# Altium schematic sheet Э3("All Documents",Physical)
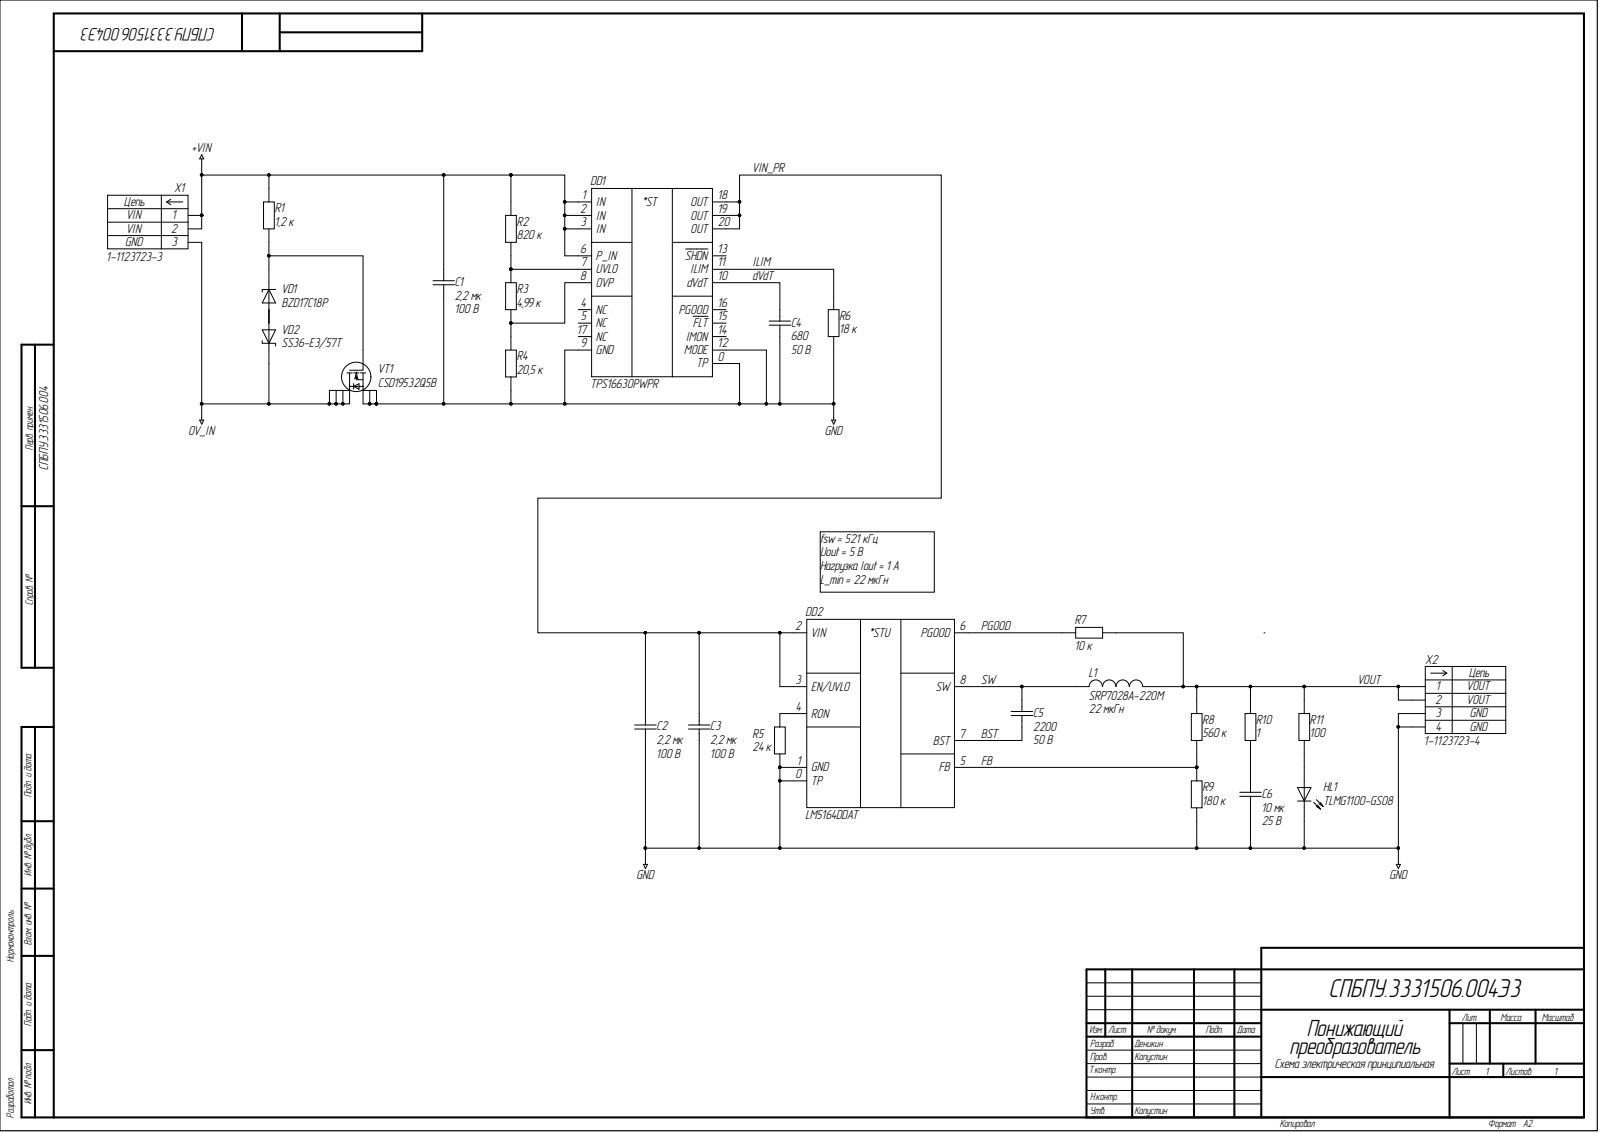
[diagram p0: Э3("All Documents",Physical)]

## Parts
C1  2,2 мк  Номинальное напряжение: 100 В  [C1206]
C2  2,2 мк  [C1206]
C3  2,2 мк  Номинальное напряжение: 100 В  [C1206]
C4  680  [C0603]
C5  2200  Номинальное напряжение: 50 В  [C0603]
C6  10 мк  Номинальное напряжение: 25 В  [C1206]
DD1  TPS16630PWPR  [HTSSOP-20]
DD2  LM5164DDAT  [HSOIC-8-P127-490X390X170-L84X41-T310X240]
HL1  TLMG1100-GS08  [HL0603]
L1  SRP7028A-220M  Номинал: 22 мкГн  [SRP-2-670X660X280]
R1  1,2 к  [R0603]
R2  820 к  [R0603]
R3  4,99 к  [R0603]
R4  20,5 к  [R0603]
R5  24 к  [R0603]
R6  18 к  [R0603]
R7  10 к  [R0603]
R8  560 к  [R0603]
R9  180 к  [R0603]
R10  1  [R0603]
R11  100  [R0603]
VD1  BZD17C18P  [DO-219AB]
VD2  SS36-E3/57T  [DO-214AB]
VT1  CSD19532Q5B  [SON-8]
X1  1-1123723-3  Contact_3: GND  Contact_2: VIN  [1-1123723-3]
X2  1-1123723-4  Contact_4: GND  Contact_2: VOUT  [1-1123723-4]

## Nets
0V_IN: VD2.1 VT1.D X1.3
+VIN: C1.2 DD1.1(IN) DD1.2(IN) DD1.3(IN) DD1.6(P_IN) R1.1 R2.1 X1.1 X1.2
GND: C1.1 C2.1 C3.1 C4.1 C6.1 DD1.0(TP) DD1.9(GND) DD1.12(MODE) DD2.0(TP) DD2.1(GND) HL1.1 R4.1 R5.2 R6.2 R9.2 VT1.S X2.3 X2.4
BST: C5.1 DD2.7(BST)
dVdT: C4.2 DD1.10(dVdT)
FB: DD2.5(FB) R8.2 R9.1
ILIM: DD1.11(ILIM) R6.1
PGOOD: DD2.6(PGOOD) R7.2
SW: C5.2 DD2.8(SW) L1.1
VIN_PR: C2.2 C3.2 DD1.18(OUT) DD1.19(OUT) DD1.20(OUT) DD2.2(VIN) DD2.3(EN/UVLO)
VOUT: L1.2 R7.1 R8.1 R10.1 R11.1 X2.1 X2.2
NetC6_2: C6.2 R10.2
NetDD1_4: DD1.4(NC)
NetDD1_5: DD1.5(NC)
NetDD1_7: DD1.7(UVLO) R2.2 R3.1
NetDD1_8: DD1.8(OVP) R3.2 R4.2
NetDD1_13: DD1.13(SHDN)
NetDD1_14: DD1.14(IMON)
NetDD1_15: DD1.15(FLT)
NetDD1_16: DD1.16(PGOOD)
NetDD1_17: DD1.17(NC)
NetDD2_4: DD2.4(RON) R5.1
NetHL1_2: HL1.2 R11.2
NetR1_2: R1.2 VD1.1 VT1.G
NetVD1_2: VD1.2 VD2.2
N00022:

## Library data of the parts
C1 = c0077: =Описание; Фамилия: Ларионов; Температурный коэффициент емкости: X7R; Рабочие температуры: от –55 °С до +125 °С; Производитель: YAGEO Corp.; Отклонение: ±10 %; Описание: Конденсатор чип 1206 2,2 мкФ 100 В ±10 %; Наименование: CC1206KKX7R0BB225; Корпус: 1206; Database Table Name: Конденсаторы$; Номинал: 2,2 мк; Напряжение: 100 В; ID: c0077; HelpURL: https://www.yageo.com/upload/media/product/productsearch/datasheet/mlcc/UPY-NP0X7R_MV_100-to-630V_22.pdf; Description: Конденсатор чип 1206 2,2 мкФ 100 В ±10 %; Component Kind: Standard; Library Name: Database_Students.DbLib
C2 = c0076: =Описание; Фамилия: Ларионов; Температурный коэффициент емкости: X7R; Рабочие температуры: от –55 °С до +125 °С; Производитель: YAGEO Corp.; Отклонение: ±10 %; Описание: Конденсатор чип 1206 2,2 мкФ 100 В ±10 %; Номинальное напряжение: 100 В; Наименование: CC1206KKX7R0BB225; Корпус: 1206; Database Table Name: Конденсаторы$; Номинал: 2,2 мк; Напряжение: 100 В; ID: c0076; HelpURL: https://www.yageo.com/upload/media/product/productsearch/datasheet/mlcc/UPY-NP0X7R_MV_100-to-630V_22.pdf; Description: Конденсатор чип 1206 2,2 мкФ 100 В ±10 %; Component Kind: Standard; Library Name: Database_Students.DbLib
C3 = c0076: =Описание; Фамилия: Ларионов; Температурный коэффициент емкости: X7R; Рабочие температуры: от –55 °С до +125 °С; Производитель: YAGEO Corp.; Отклонение: ±10 %; Описание: Конденсатор чип 1206 2,2 мкФ 100 В ±10 %; Наименование: CC1206KKX7R0BB225; Корпус: 1206; Database Table Name: Конденсаторы$; Номинал: 2,2 мк; Напряжение: 100 В; ID: c0076; HelpURL: https://www.yageo.com/upload/media/product/productsearch/datasheet/mlcc/UPY-NP0X7R_MV_100-to-630V_22.pdf; Description: Конденсатор чип 1206 2,2 мкФ 100 В ±10 %; Component Kind: Standard; Library Name: Database_Students.DbLib
C4 = c0005: =Описание; Фамилия: Демчева; Температурный коэффициент емкости: X7R; Рабочие температуры: от –55 °С до +125 °С; Производитель: YAGEO Corp.; Отклонение: ±5 %; Описание: Конденсатор чип 0603 680 пФ 50 В ±5 %; Номинальное напряжение: 50 В; Наименование: CC0603JRX7R9BB681; Корпус: 0603; Database Table Name: Конденсаторы$; Номинал: 680; Напряжение: 50 В; ID: c0005; HelpURL: https://www.yageo.com/upload/media/product/productsearch/datasheet/mlcc/UPY-GPHC_X7R_6.3V-to-50V_20.pdf; Description: Конденсатор чип 0603 680 пФ 50 В ±5 %; Component Kind: Standard; Library Name: Database_Students.DbLib
C5 = c0019: =Описание; Фамилия: Паньков; Температурный коэффициент емкости: X7R; Рабочие температуры: от –55 °С до +125 °С; Производитель: YAGEO Corp.; Отклонение: ±10 %; Описание: Конденсатор чип 0603 2,2 нФ 50 В ±10 %; Наименование: CC0603KRX7R9BB222; Корпус: 0603; Database Table Name: Конденсаторы$; Номинал: 2200; Напряжение: 50 В; ID: c0019; HelpURL: https://www.yageo.com/upload/media/product/products/datasheet/mlcc/UPY-GPHC_X7R_6.3V-to-50V_20.pdf; Description: Конденсатор чип 0603 2,2 нФ 50 В ±10 %; Component Kind: Standard; Library Name: Database_Students.DbLib
C6 = c0095: =Описание; Фамилия: Паньков; Температурный коэффициент емкости: X7R; Рабочие температуры: от –55 °С до +125 °С; Производитель: YAGEO Corp.; Отклонение: ±10 %; Описание: Конденсатор чип 1206 10 мкФ 25 В ±10 %; Наименование: CC1206KRX7R8BB106; Корпус: 1206; Database Table Name: Конденсаторы$; Номинал: 10 мк; Напряжение: 25 В; ID: c0095; HelpURL: https://www.yageo.com/upload/media/product/productsearch/datasheet/mlcc/UPY-GPHC_X7R_6.3V-to-50V_20.pdf; Description: Конденсатор чип 1206 10 мкФ 25 В ±10 %; Component Kind: Standard; Library Name: Database_Students.DbLib
DD1 = dd0002: =Описание; Фамилия: Жернаков; Ток: от 0,6 А до 6 А; Рабочие температуры: от -40 °С до +125 °С; Производитель: Texas Instruments; Описание: Защитная микросхема eFuse 60 В и 6 А; Напряжение: от 4,5 В до 60 В; Максимальное напряжение: 67 В; Database Table Name: Защитные микросхемы$; Наименование: TPS16630PWPR; Корпус: HTSSOP-20; ID: dd0002; HelpURL: https://www.ti.com/product/TPS1663; Description: Защитная микросхема eFuse 60 В и 6 А; Component Kind: Standard; Library Name: Database_Students.DbLib
DD2 = dd0025: =Описание; Частота переключения: от 75 кГц до 1,0 МГц; Фамилия: Деникин; Рабочие температуры: от –40 °С до +150 °С; Производитель: Texas Instruments Inc.; Описание: Понижающий преобразователь напряжения 6,0…100 В / 1,2…90 В и 1,0 А; Корпус: HSOIC-8; Коммутируемый ток: до 1,0 А; Выходное напряжение: от 1,2 В до 90 В; Входное напряжение: от 6,0 В до 100 В; Database Table Name: Преобразователи напряжения$; Наименование: LM5164DDAT; ID: dd0025; HelpURL: https://www.ti.com/product/LM5164; Description: Понижающий преобразователь напряжения 6,0…100 В / 1,2…90 В и 1,0 А; Component Kind: Standard; Library Name: Database_Students.DbLib
HL1 = hl0005: =Описание; Цвет: Green; Фамилия: Ларионов; Рассеиваемая мощность: 90 мВт; Рабочие температуры: от -40 °С до +100 °С; Производитель: Vishay Intertechnology, Inc.; Описание: Светодиод чип 0603 зеленый; Номинальный прямой ток: 30 мА; Номинальное падение напряжения: 2,1 В; Номинальная сила света: 35 мкд; Номинальная длина волны: 570 нм; Корпус: 0603; Database Table Name: Светодиоды$; Наименование: TLMG1100-GS08; ID: hl0005; HelpURL: https://www.vishay.com/leds/list/product-83173/; Description: Светодиод чип 0603 зеленый; Component Kind: Standard; Library Name: Database_Students.DbLib
L1 = l0005: =Описание; Фамилия: Ларионов; Ток насыщения: 3,5 А; Резонансная частота: 10 МГц; Рабочие температуры: от –55 °С до +150 °С; Рабочее напряжение: не указано; Производитель: Bourns, Inc.; Отклонение: ±20 %; Описание: Катушка индуктивности 22 мкГн ±20 %; Номинальный ток (при нагреве на 20 °С/40 °С): 2 А; Номинальное активное сопротивление: 165 мОм; Максимальное активное сопротивление: 190 мОм; Корпус: 6,7 x 6,6 x 2,8; Database Table Name: Катушки индуктивности$; Наименование: SRP7028A-220M; Индуктивность: 22 мкГн; ID: l0005; HelpURL: https://www.bourns.com/products/magnetic-products/power-inductors-aec-q200-compliant/product/SRP7028A; Description: Катушка индуктивности 22 мкГн ±20 %; Component Kind: Standard; Library Name: Database_Students.DbLib
R1 = r0034: =Описание; Фамилия: Паньков; Рабочие температуры: от –55 °С до +155 °С; Производитель: YAGEO Corp.; Отклонение: ±1 %; Описание: Резистор чип 0603 1,2 кОм ±1 % 0,1 Вт; Номинальный ток: 1 А; Номинальное напряжение: 75 В; Наименование: RC0603FR-071K2L; Корпус: 0603; Database Table Name: Резисторы$; Номинал: 1,2 к; ID: r0034; HelpURL: https://www.yageo.com/upload/media/product/productsearch/datasheet/rchip/PYu-RC_Group_51_RoHS_L_11.pdf; Description: Резистор чип 0603 1,2 кОм ±1 % 0,1 Вт; Component Kind: Standard; Library Name: Database_Students.DbLib
R2 = r0124: =Описание; Фамилия: Паньков; Рабочие температуры: от –55 °С до +155 °С; Производитель: YAGEO Corp.; Примечание: Ряд E24; Отклонение: ±1 %; Описание: Резистор чип 0603 820 кОм ±1 % 0,1 Вт; Номинальный ток: 1 А; Номинальное напряжение: 75 В; Наименование: RC0603FR-07820KL; Корпус: 0603; Database Table Name: Резисторы$; Номинал: 820 к; ID: r0124; HelpURL: https://www.yageo.com/upload/media/product/productsearch/datasheet/rchip/PYu-RC_Group_51_RoHS_L_11.pdf; Description: Резистор чип 0603 820 кОм ±1 % 0,1 Вт; Component Kind: Standard; Library Name: Database_Students.DbLib
R3 = r0050: =Описание; Фамилия: Жернаков; Рабочие температуры: от –55 °С до +155 °С; Производитель: YAGEO Corp.; Отклонение: ±1 %; Описание: Резистор чип 0603 4,99 кОм ±1 % 0,1 Вт; Номинальный ток: 1 А; Номинальное напряжение: 75 В; Наименование: RC0603FR-074K99L; Корпус: 0603; Database Table Name: Резисторы$; Номинал: 4,99 к; ID: r0050; HelpURL: https://www.yageo.com/upload/media/product/productsearch/datasheet/rchip/PYu-RC_Group_51_RoHS_L_11.pdf; Description: Резистор чип 0603 4,99 кОм ±1 % 0,1 Вт; Component Kind: Standard; Library Name: Database_Students.DbLib
R4 = r0071: =Описание; Фамилия: Демчева; Рабочие температуры: от –55 °С до +155 °С; Производитель: YAGEO Corp.; Отклонение: ±1 %; Описание: Резистор чип 0603 20,5 кОм  ±1 % 0,1 Вт; Номинальный ток: 1 А; Номинальное напряжение: 75 В; Наименование: RC0603FR-0720K5L; Корпус: 0603; Database Table Name: Резисторы$; Номинал: 20,5 к; ID: r0071; HelpURL: https://ru.mouser.com/ProductDetail/Yageo/RC0603FR-0720K5L/?qs=VU8sRB4EgwDkGE%252BE2CpPDg%3D%3D; Description: Резистор чип 0603 20,5 кОм  ±1 % 0,1 Вт; Component Kind: Standard; Library Name: Database_Students.DbLib
R5 = r0072: =Описание; Фамилия: Паньков; Рабочие температуры: от –55 °С до +155 °С; Производитель: YAGEO Corp.; Отклонение: ±1 %; Описание: Резистор чип 0603 24 кОм ±1 % 0,1 Вт; Номинальный ток: 1 А; Номинальное напряжение: 75 В; Наименование: RC0603FR-0724KL; Корпус: 0603; Database Table Name: Резисторы$; Номинал: 24 к; ID: r0072; HelpURL: https://www.yageo.com/upload/media/product/productsearch/datasheet/rchip/PYu-RC_Group_51_RoHS_L_11.pdf; Description: Резистор чип 0603 24 кОм ±1 % 0,1 Вт; Component Kind: Standard; Library Name: Database_Students.DbLib
R6 = r0068: =Описание; Фамилия: Паньков; Рабочие температуры: от –55 °С до +155 °С; Производитель: YAGEO Corp.; Примечание: Ряд E24; Отклонение: ±1 %; Описание: Резистор чип 0603 18 кОм ±1 % 0,1 Вт; Номинальный ток: 1 А; Номинальное напряжение: 75 В; Наименование: RC0603FR-0718KL; Корпус: 0603; Database Table Name: Резисторы$; Номинал: 18 к; ID: r0068; HelpURL: https://www.yageo.com/upload/media/product/productsearch/datasheet/rchip/PYu-RC_Group_51_RoHS_L_11.pdf; Description: Резистор чип 0603 18 кОм ±1 % 0,1 Вт; Component Kind: Standard; Library Name: Database_Students.DbLib
R7 = r0060: =Описание; Фамилия: Самарин; Рабочие температуры: от –55 °С до +155 °С; Производитель: YAGEO Corp.; Примечание: Ряд E24; Отклонение: ±1 %; Описание: Резистор чип 0603 10 кОм ±1 % 0,1 Вт; Номинальный ток: 1 А; Номинальное напряжение: 75 В; Наименование: RC0603FR-0710KL; Корпус: 0603; Database Table Name: Резисторы$; Номинал: 10 к; ID: r0060; HelpURL: https://www.yageo.com/upload/media/product/productsearch/datasheet/rchip/PYu-RC_Group_51_RoHS_L_11.pdf; Description: Резистор чип 0603 10 кОм ±1 % 0,1 Вт; Component Kind: Standard; Library Name: Database_Students.DbLib
R8 = r0120: =Описание; Фамилия: Паньков; Рабочие температуры: от –55 °С до +155 °С; Производитель: YAGEO Corp.; Отклонение: ±1 %; Описание: Резистор чип 0603 560 кОм ±1 % 0,1 Вт; Номинальный ток: 1 А; Номинальное напряжение: 75 В; Наименование: RC0603FR-07560KL; Корпус: 0603; Database Table Name: Резисторы$; Номинал: 560 к; ID: r0120; HelpURL: https://www.yageo.com/upload/media/product/productsearch/datasheet/rchip/PYu-RC_Group_51_RoHS_L_11.pdf; Description: Резистор чип 0603 560 кОм ±1 % 0,1 Вт; Component Kind: Standard; Library Name: Database_Students.DbLib
R9 = r0105: =Описание; Фамилия: Паньков; Рабочие температуры: от –55 °С до +155 °С; Производитель: YAGEO Corp.; Отклонение: ±1 %; Описание: Резистор чип 0603 180 кОм ±1 % 0,1 Вт; Номинальный ток: 1 А; Номинальное напряжение: 75 В; Наименование: RC0603FR-07180KL; Корпус: 0603; Database Table Name: Резисторы$; Номинал: 180 к; ID: r0105; HelpURL: https://www.yageo.com/upload/media/product/productsearch/datasheet/rchip/PYu-RC_Group_51_RoHS_L_11.pdf; Description: Резистор чип 0603 180 кОм ±1 % 0,1 Вт; Component Kind: Standard; Library Name: Database_Students.DbLib
R10 = r0002: =Описание; Фамилия: Ларионов; Рабочие температуры: от –55 °С до +155 °С; Производитель: YAGEO Corp.; Отклонение: ±1 %; Описание: Резистор чип 0603 1 Ом ±1 % 0,1 Вт; Номинальный ток: 1 А; Номинальное напряжение: 75 В; Наименование: RC0603FR-071RL; Корпус: 0603; Database Table Name: Резисторы$; Номинал: 1; ID: r0002; HelpURL: https://www.yageo.com/upload/media/product/productsearch/datasheet/rchip/PYu-RC_Group_51_RoHS_L_11.pdf; Description: Резистор чип 0603 1 Ом ±1 % 0,1 Вт; Component Kind: Standard; Library Name: Database_Students.DbLib
R11 = r0007: =Описание; Фамилия: Самарин; Рабочие температуры: от –55 °С до +155 °С; Производитель: YAGEO Corp.; Примечание: Ряд E24; Отклонение: ±1 %; Описание: Резистор чип 0603 100 Ом ±1 % 0,1 Вт; Номинальный ток: 1 А; Номинальное напряжение: 75 В; Наименование: RC0603FR‍‐07100RL; Корпус: 0603; Database Table Name: Резисторы$; Номинал: 100; ID: r0007; HelpURL: https://www.yageo.com/upload/media/product/productsearch/datasheet/rchip/PYu-RC_Group_51_RoHS_L_11.pdf; Description: Резистор чип 0603 100 Ом ±1 % 0,1 Вт; Component Kind: Standard; Library Name: Database_Students.DbLib
VD1 = vd0018: =Описание; Фамилия: Паньков; Разброс напряжения стабилизации: 16,8...19,1 В; Рабочие температуры: от –55 °С до +150 °С; Производитель: Vishay Intertechnology, Inc.; Описание: Стабилитрон 18 В; Номинальный ток стабилизации: 25 мА; Номинальное напряжение стабилизации: 18 В; Database Table Name: Стабилитроны$; Наименование: BZD17C18P; Корпус: DO-219AB; ID: vd0018; HelpURL: https://www.vishay.com/product?docid=85161; Description: Стабилитрон 18 В; Component Kind: Standard; Library Name: Database_Students.DbLib
VD2 = vd0004: =Описание; Фамилия: Жернаков; Рабочие температуры: от –55 °С до +150 °С; Производитель: Vishay Intertechnology, Inc.; Пиковое прямое напряжение: 0,75 В; Описание: Диод Шоттки 60 В 3 А; Макс допустимый средний прямой ток: 3 А; Импульсное обратное напряжение: 60 В; Database Table Name: Диоды$; Наименование: SS36-E3/57T; Корпус: DO-214AB; ID: vd0004; HelpURL: https://www.vishay.com/product?docid=88751; Description: Диод Шоттки 60 В 3 А; Component Kind: Standard; Library Name: Database_Students.DbLib
VT1 = vt0001: =Описание; Фамилия: Жернаков; Ток стока: 17 А; Сопротивление сток — исток: 0,0057 Ом; Рабочие температуры: от –55 °С до +150 °С; Производитель: Texas Instruments; Описание: Транзистор полевой N-канальный 100 В 17 А; Напряжение сток — исток: 100 В; Напряжение затвор — исток: ±20 В; Database Table Name: Транзисторы$; Наименование: CSD19532Q5B; Корпус: SON-8; ID: vt0001; HelpURL: https://www.ti.com/product/CSD19532Q5B; Description: Транзистор полевой N-канальный 100 В 17 А; Component Kind: Standard; Library Name: Database_Students.DbLib
X1 = x0006: =Описание; Фамилия: Ларионов; Производитель: TE Connectivity; Описание: Economy Power 3 pin 3,96 мм вилка прямой; Database Table Name: Разъемы$; Наименование: 1-1123723-3; ID: x0006; HelpURL: https://www.te.com/usa-en/product-1-1123723-3.html; Description: Economy Power 3 pin 3,96 мм вилка прямой; Contact_1: VIN; Component Kind: Standard; Library Name: Database_Students.DbLib
X2 = x0007: =Описание; Фамилия: Ларионов; Производитель: TE Connectivity; Описание: Economy Power 4 pin 3,96 мм вилка прямой; Database Table Name: Разъемы$; Наименование: 1-1123723-4; ID: x0007; HelpURL: https://www.te.com/usa-en/product-1-1123723-4.html; Description: Economy Power 4 pin 3,96 мм вилка прямой; Contact_3: GND; Contact_1: VOUT; Component Kind: Standard; Library Name: Database_Students.DbLib
